# Altium schematic sheet Pwm.SchDoc(Pwm)
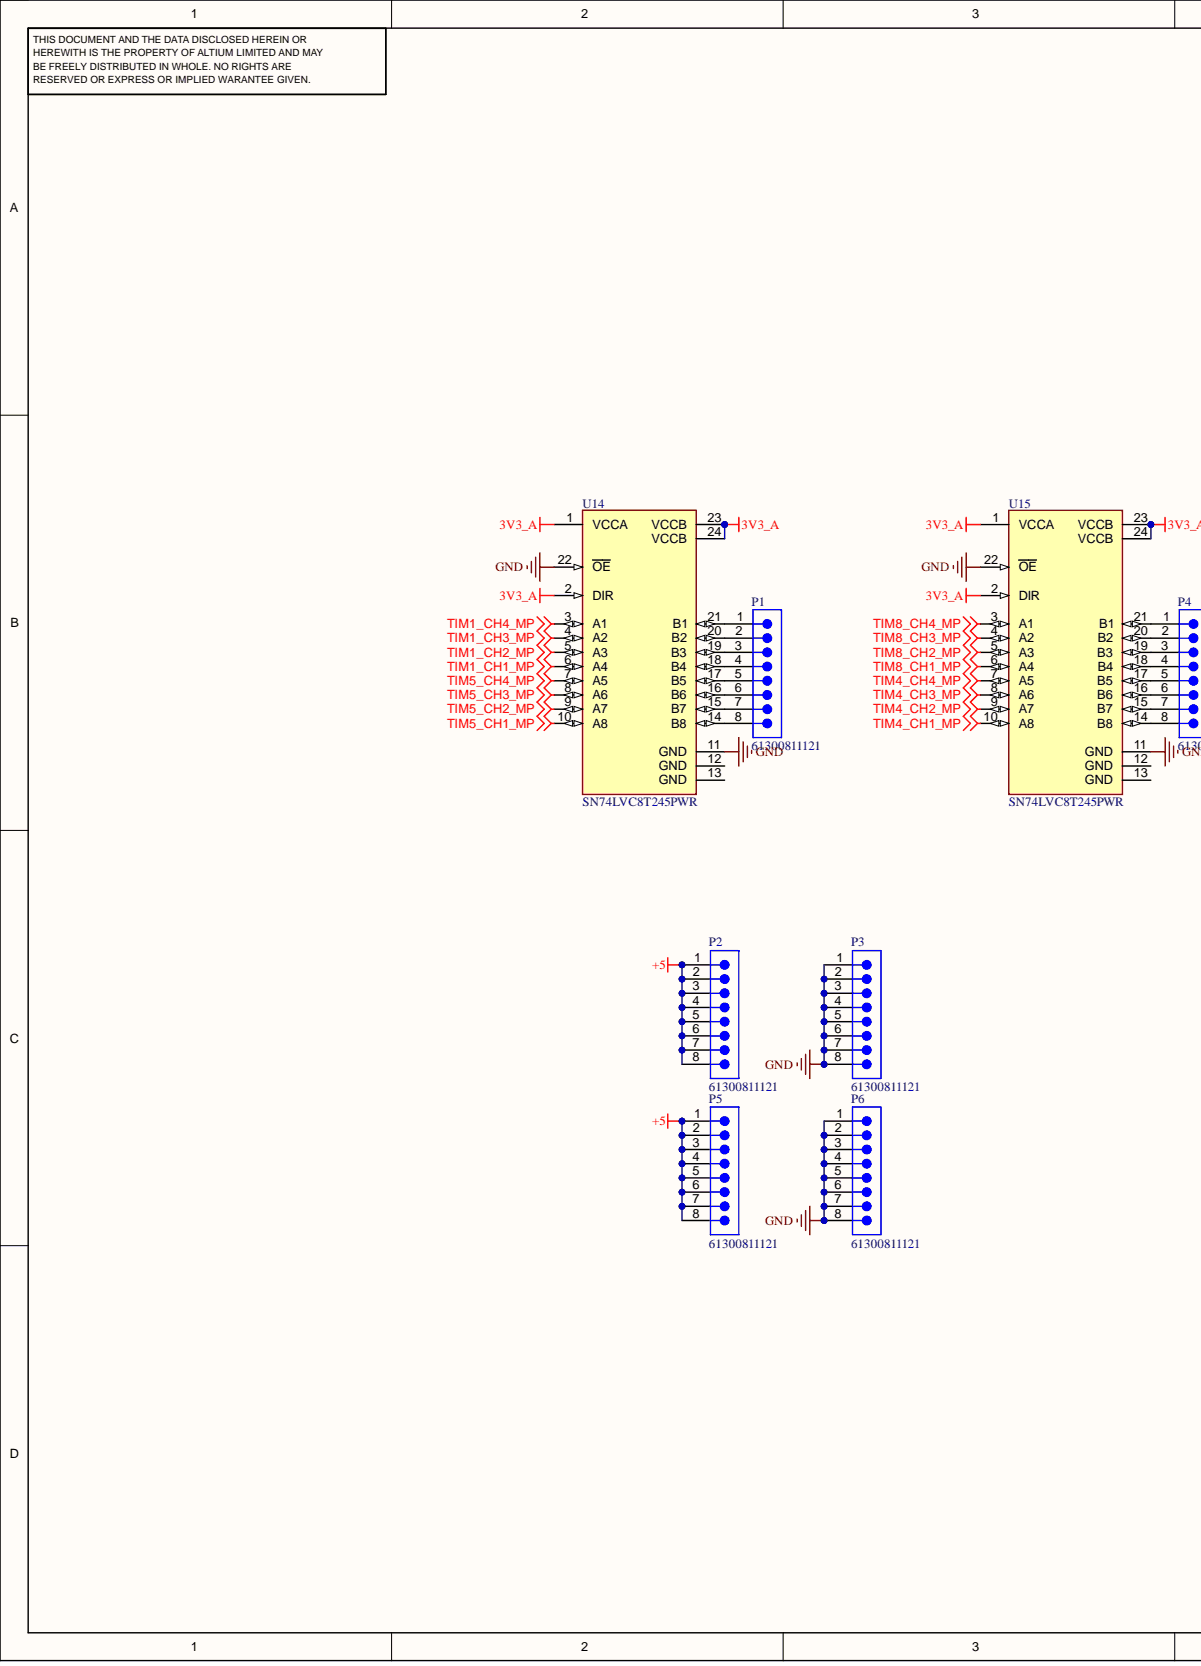
[diagram p0: Pwm.SchDoc, left]
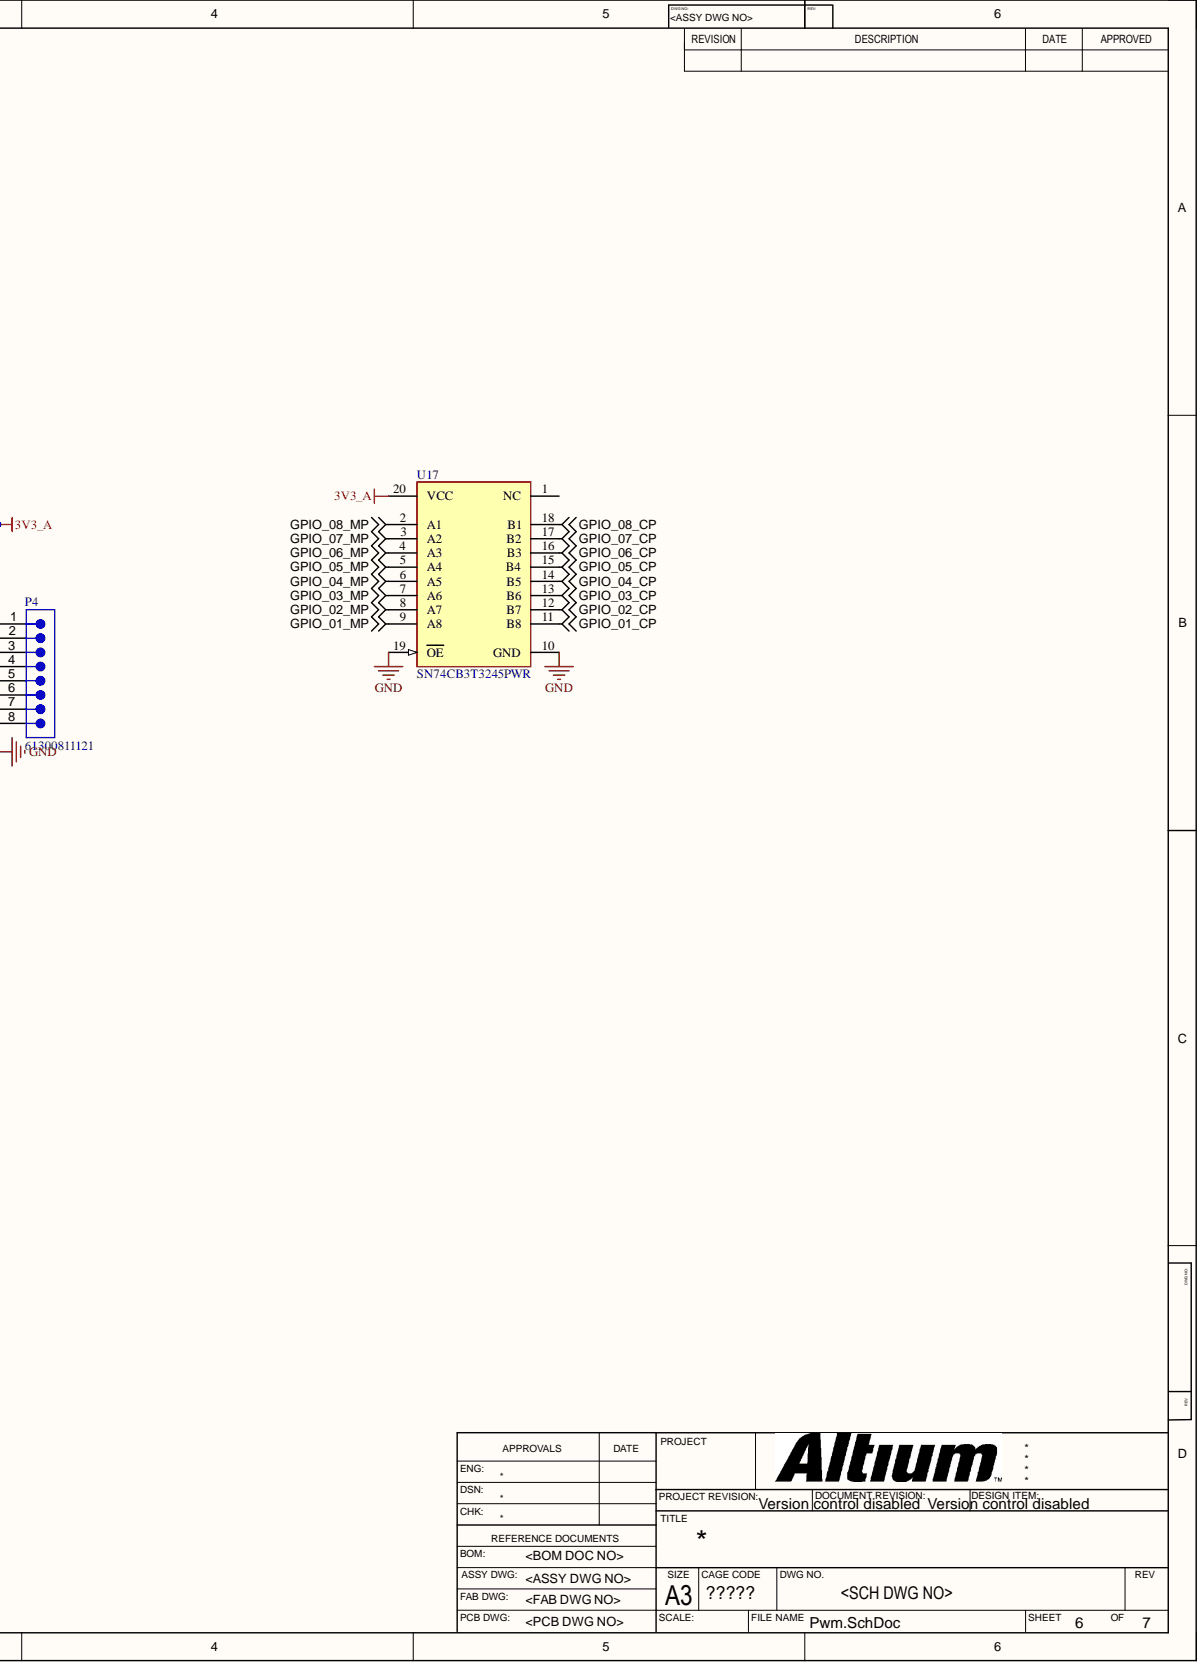
[diagram p1: Pwm.SchDoc, right]

## Parts
P1  61300811121
P2  61300811121
P3  61300811121
P4  61300811121
P5  61300811121
P6  61300811121
U14  SN74LVC8T245PWR
U15  SN74LVC8T245PWR
U17  SN74CB3T3245PWR

## Nets
3V3_A: U14.1(VCCA) U14.2(DIR) U14.23(VCCB) U14.24(VCCB) U15.1(VCCA) U15.2(DIR) U15.23(VCCB) U15.24(VCCB) U17.20(VCC)
+5: P2.1 P2.2 P2.3 P2.4 P2.5 P2.6 P2.7 P2.8 P5.1 P5.2 P5.3 P5.4 P5.5 P5.6 P5.7 P5.8
GND: P3.1 P3.2 P3.3 P3.4 P3.5 P3.6 P3.7 P3.8 P6.1 P6.2 P6.3 P6.4 P6.5 P6.6 P6.7 P6.8 U14.11(GND) U14.22(OE) U15.11(GND) U15.22(OE) U17.10(GND) U17.19(OE)
GPIO_01_CP: U17.11(B8)
GPIO_01_MP: U17.9(A8)
GPIO_02_CP: U17.12(B7)
GPIO_02_MP: U17.8(A7)
GPIO_03_CP: U17.13(B6)
GPIO_03_MP: U17.7(A6)
GPIO_04_CP: U17.14(B5)
GPIO_04_MP: U17.6(A5)
GPIO_05_CP: U17.15(B4)
GPIO_05_MP: U17.5(A4)
GPIO_06_CP: U17.16(B3)
GPIO_06_MP: U17.4(A3)
GPIO_07_CP: U17.17(B2)
GPIO_07_MP: U17.3(A2)
GPIO_08_CP: U17.18(B1)
GPIO_08_MP: U17.2(A1)
TIM1_CH1_MP: U14.6(A4)
TIM1_CH2_MP: U14.5(A3)
TIM1_CH3_MP: U14.4(A2)
TIM1_CH4_MP: U14.3(A1)
TIM4_CH1_MP: U15.10(A8)
TIM4_CH2_MP: U15.9(A7)
TIM4_CH3_MP: U15.8(A6)
TIM4_CH4_MP: U15.7(A5)
TIM5_CH1_MP: U14.10(A8)
TIM5_CH2_MP: U14.9(A7)
TIM5_CH3_MP: U14.8(A6)
TIM5_CH4_MP: U14.7(A5)
TIM8_CH1_MP: U15.6(A4)
TIM8_CH2_MP: U15.5(A3)
TIM8_CH3_MP: U15.4(A2)
TIM8_CH4_MP: U15.3(A1)
NetP1_1: P1.1 U14.21(B1)
NetP1_2: P1.2 U14.20(B2)
NetP1_3: P1.3 U14.19(B3)
NetP1_4: P1.4 U14.18(B4)
NetP1_5: P1.5 U14.17(B5)
NetP1_6: P1.6 U14.16(B6)
NetP1_7: P1.7 U14.15(B7)
NetP1_8: P1.8 U14.14(B8)
NetP4_1: P4.1 U15.21(B1)
NetP4_2: P4.2 U15.20(B2)
NetP4_3: P4.3 U15.19(B3)
NetP4_4: P4.4 U15.18(B4)
NetP4_5: P4.5 U15.17(B5)
NetP4_6: P4.6 U15.16(B6)
NetP4_7: P4.7 U15.15(B7)
NetP4_8: P4.8 U15.14(B8)

Not connected: U14 12(GND) 13(GND); U15 12(GND) 13(GND); U17 1(NC)

## Library data of the parts
P1-P6 = CMP-1502-01076-3: NexarPartId: 32855533; Connector Type: Male Pin; Contact Resistance: 20mΩ; Current Rating: 3A; Gender: Male; Number of Contacts: 8; Operating Supply Voltage: 250V; Pitch: 2.54mm; Color: Black; Component Kind: Standard; Contact Material: Copper; Contact Mating Length: 5.9944mm; Contact Plating: Gold; Height: 8.54mm; Lead Pitch: 2.54mm; Library Name: Altium Content Vault; Max Operating Temperature: 105°C; Min Operating Temperature: -40°C; Mount: Through Hole; Number of Pins: 8; Number of Positions: 8; Number of Rows: 1; Orientation: Vertical; Packaging: Bulk; REACH SVHC: Unknown; RoHS: Compliant; Termination: Solder; Voltage Rating: 250V
U14 U15 = CMP-04899-000027-1: IC TRNSLTR BIDIRECTIONAL 24TSSOP; Manufacturer: Texas Instruments; Logic Function Desc: Non-Inverting; Max Supply Voltage: 5.5V; Min Supply Voltage: 1.65V; Nominal Supply Current: 25uA; Number Of Circuits: 1; Number Of Inputs: 8; Propagation Delay: 4.3ns; Standoff Height: 0.05mm; Ambient Temperature Range High: +85°C; Ambient Temperature Range Low: -40°C; Automotive: No; Category: IC; Component Kind: Standard; ComponentLink2Description: Datasheet; ComponentLink2URL: http://www.ti.com/lit/ds/symlink/sn74lvc8t245.pdf; Device Class L1: Integrated Circuits (ICs); Device Class L2: Logic ICs; Device Class L3: Voltage Level Shifters; Digikey Description: IC TRNSLTR BIDIRECTIONAL 24TSSOP; Height: 1.2mm; Ipc Land Pattern Name: SOP65P640X120-24; Lead Free: Yes; Library Name: Altium Content Vault; Manufacturer Part Number: SN74LVC8T245PWR; Mouser Description: Translation - Voltage Levels 8-Bit Dual-Supply Bus Xcvr; Number Of Outputs: 8; Octopart: https://octopart.com/sn74lvc8t245pwr-texas+instruments-517164; Package: PW0024A; RoHS: TRUE; Temperature: -40°C to +85°C; Temperature Range High: +85°C; Temperature Range Low: -40°C; V A Max: 5.5V; V A Min: 1.65V; V B Max: 5.5V; V B Min: 1.65V
U17 = CMP-0775-00065-4: IC 2.5/3.3V FET BUS SW 20-TSSOP; Bandwidth: 100MHz; High Level Output Current: -128mA; Independent Circuits: 1; Low Level Output Current: 128mA; Max Supply Voltage: 3.6V; Min Supply Voltage: 2.3V; Nominal Supply Current: 40uA; Number of Bits: 8; On-State Resistance: 8.5R; Output Current: 64mA; Polarity: Non-Inverting; Propagation Delay: 10.5ns; Supply Type: Single; Throw Configuration: SPST; Case/Package: TSSOP; Component Kind: Standard; Contact Plating: Gold; Lead Free: Lead Free; Library Name: Altium Content Vault; Max Operating Temperature: 85°C; Min Operating Temperature: -40°C; Mount: Surface Mount; Number of Channels: 8; Number of Elements: 1; Number of Pins: 20; Number of Switches: 8; Package Quantity: 1; Packaging: Tape and Reel; Quiescent Current: 40uA; Radiation Hardening: No; RoHS Compliant: Yes; Series: 74CB; Supply Current: 40uA; Technology: CMOS; Turn-On Delay Time: 10.5ns; Weight: 0.002716oz
